# Altium schematic sheet 计算机原理课程设计2.SchDoc
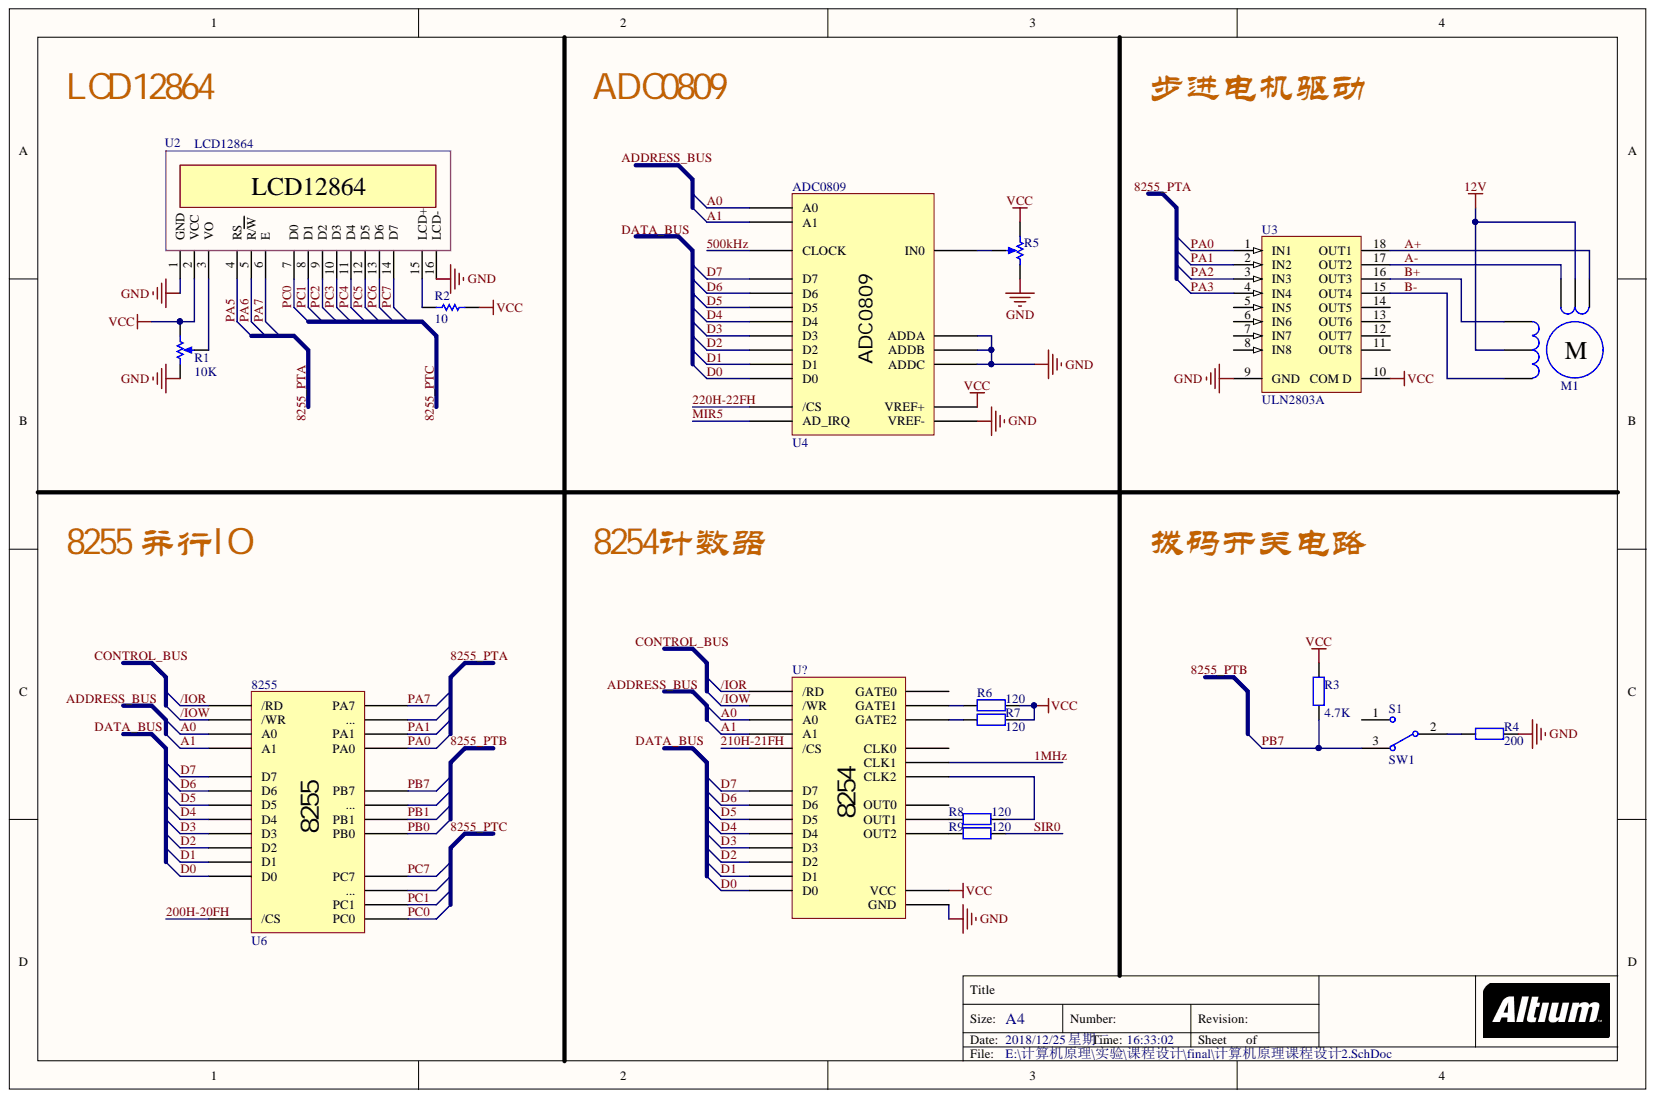
[diagram p0: 计算机原理课程设计2.SchDoc]

## Parts
8255  U6
ADC0809  U4
M1  MOTOR STEPPER  (value not drawn)
R1  value 10K  [VR5]  (hidden comment: RPot)
R2  value 10  [AXIAL-0.3]  (hidden comment: Res1)
R3  value 4.7K  [AXIAL-0.4]  (hidden comment: Res2)
R4  value 200  [AXIAL-0.4]  (hidden comment: Res2)
R5  RPot  [VR5]  (value not drawn)
R6  value 120  [AXIAL-0.4]  (hidden comment: Res2)
R7  value 120  [AXIAL-0.4]  (hidden comment: Res2)
R8  value 120  [AXIAL-0.4]  (hidden comment: Res2)
R9  value 120  [AXIAL-0.4]  (hidden comment: Res2)
S1  SW1  [TL36WW15050]
U2  LCD12864  [LCD1602]
U3  ULN2803A  [A18]
U?  8253A  (value not drawn)

## Nets
12V: M1.2 M1.5(M)
GND: ADC0809.GND R1.1 R4.1 R5.2 U2.1(GND) U2.16(LCD-) U3.9(GND) U?.GND
VCC: ADC0809.GND R1.2 R2.1 R3.2 R5.1 R6.2 R7.2 U2.2(VCC) U3.10(COM_D) U?.GND
1MHz: U?.GND
200H-20FH: 8255.GND
210H-21FH: U?.GND
220H-22FH: ADC0809.GND
500kHz: ADC0809.GND
/IOR: 8255.GND U?.GND
/IOW: 8255.GND U?.GND
A0: 8255.GND ADC0809.GND U?.GND
A1: 8255.GND ADC0809.GND U?.GND
A+: M1.1 U3.18(OUT1)
A-: M1.3 U3.17(OUT2)
B+: M1.4 U3.16(OUT3)
B-: M1.6 U3.15(OUT4)
D0: 8255.GND ADC0809.GND U?.GND
D1: 8255.GND ADC0809.GND U?.GND
D2: 8255.GND ADC0809.GND U?.GND
D3: 8255.GND ADC0809.GND U?.GND
D4: 8255.GND ADC0809.GND U?.GND
D5: 8255.GND ADC0809.GND U?.GND
D6: 8255.GND ADC0809.GND U?.GND
D7: 8255.GND ADC0809.GND U?.GND
MIR5: ADC0809.GND
PA0: 8255.GND U3.1(IN1)
PA1: 8255.GND U3.2(IN2)
PA2: U3.3(IN3)
PA3: U3.4(IN4)
PA5: U2.4(RS)
PA6: U2.5(R/W)
PA7: 8255.GND U2.6(E)
PB0: 8255.GND
PB1: 8255.GND
PB7: 8255.GND R3.1 S1.3
PC0: 8255.GND U2.7(D0)
PC1: 8255.GND U2.8(D1)
PC2: U2.9(D2)
PC3: U2.10(D3)
PC4: U2.11(D4)
PC5: U2.12(D5)
PC6: U2.13(D6)
PC7: 8255.GND U2.14(D7)
SIR0: R9.2
NetADC0809_GND: ADC0809.GND R5.3
NetR1_3: R1.3 U2.3(VO)
NetR2_2: R2.2 U2.15(LCD+)
NetR4_2: R4.2 S1.2
NetR6_1: R6.1 U?.GND
NetR7_1: R7.1 U?.GND
NetR8_1: R8.1 U?.GND
NetR8_2: R8.2 U?.GND
NetR9_1: R9.1 U?.GND
8255_PTA:
8255_PTB:
8255_PTC:
ADDRESS_BUS:
CONTROL_BUS:
DATA_BUS:
N00118:
N00119:
N00120:
N00121:
N00122:
N00123:
N00124:
N00125:
N00126:
N00127:
N00128:
N00129:
N00130:
N00131:
N00132:

Not connected: S1 1; U3 5(IN5) 6(IN6) 7(IN7) 8(IN8) 11(OUT8) 12(OUT7) 13(OUT6) 14(OUT5)

## Library data of the parts
8255 = 8255: Component Kind: Standard; Library Name: 计算机原理实验4.SchLib
ADC0809 = ADC0809: Component Kind: Standard; Library Name: 计算机原理实验5.SchLib
R1 = RPot: Potentiometer; Set Position: 0.5; Sim Note: Enter the Resistance value and the set point into parameter fields.; Component Kind: Standard; LatestRevisionDate: 17-Jul-2002; LatestRevisionNote: Re-released for DXP Platform.; Library Name: Miscellaneous Devices.IntLib; PackageDescription: Variable Resistor, Thru-Hole; 3 Leads; PackageReference: VR5; Published: 8-Jun-2000; Simulation: POT; Publisher: Altium Limited
R2 = Res1: Resistor; Component Kind: Standard; LatestRevisionDate: 17-Jul-2002; LatestRevisionNote: Re-released for DXP Platform.; Library Name: Miscellaneous Devices.IntLib; PackageDescription: Axial Device, Thru-Hole; 2 Leads; 0.3 in Pin Spacing; PackageReference: AXIAL-0.3; Published: 8-Jun-2000; Signal Integrity: Res; Simulation: RESISTOR; Publisher: Altium Limited
R3 R4 R6-R9 = Res2: Resistor; Component Kind: Standard; LatestRevisionDate: 17-Jul-2002; LatestRevisionNote: Re-released for DXP Platform.; Library Name: Miscellaneous Devices.IntLib; PackageDescription: Axial Device, Thru-Hole; 2 Leads; 0.4 in Pin Spacing; PackageReference: AXIAL-0.4; Published: 8-Jun-2000; Signal Integrity: Res; Simulation: RESISTOR; Publisher: Altium Limited
R5 = RPot: Potentiometer; Set Position: 0.5; Sim Note: Enter the Resistance value and the set point into parameter fields.; Value: 10K; Component Kind: Standard; LatestRevisionDate: 17-Jul-2002; LatestRevisionNote: Re-released for DXP Platform.; Library Name: Miscellaneous Devices.IntLib; PackageDescription: Variable Resistor, Thru-Hole; 3 Leads; PackageReference: VR5; Published: 8-Jun-2000; Simulation: POT; Publisher: Altium Limited
S1 = SW-SPDT: SPDT Subminiature Toggle Switch, Right Angle Mounting, Vertical Actuation; Component Kind: Standard; ComponentLink1Description: Manufacturer Link; ComponentLink1URL: http://www.apem.com; DatasheetVersion: 05-Dec-2000; LatestRevisionDate: 17-Dec-2003; LatestRevisionNote: Schematic revised, PCB Footprint replaced.; Library Name: Miscellaneous Devices.IntLib; PackageDescription: Switch; 4 Leads; PackageReference: TL36WW15050; Published: 24-Mar-1999; Publisher: Altium Limited
U2 = LCD1602: Component Kind: Standard; Library Name: My Footprint.IntLib
U3 = ULN2803A: High-Voltage, High-Current Darlington Array; Component Kind: Standard; ComponentLink1Description: Manufacturer Link; ComponentLink1URL: http://www.allegromicro.com/; DatasheetVersion: 1999; LatestRevisionDate: 06-Jun-2005; LatestRevisionNote: Stylized 3D Model Added.; PackageDescription: DIP; 18 Leads; Row Spacing 7.62 mm; Pitch 2.54 mm; PackageReference: A18; PackageVersion: 1999; PCB3D: A18; Published: 13-Oct-2000; Library Name: Allegro Interface Darlington Driver.IntLib; Publisher: Altium Limited
M1 = MOTOR STEPPER: Component Kind: Standard; Library Name: Miscellaneous Devices.SCHLIB
U? = 8253A: Component Kind: Standard; Library Name: 计算机原理课程设计.SCHLIB
